# Altium schematic sheet Sheet1.SchDoc(Sheet1)
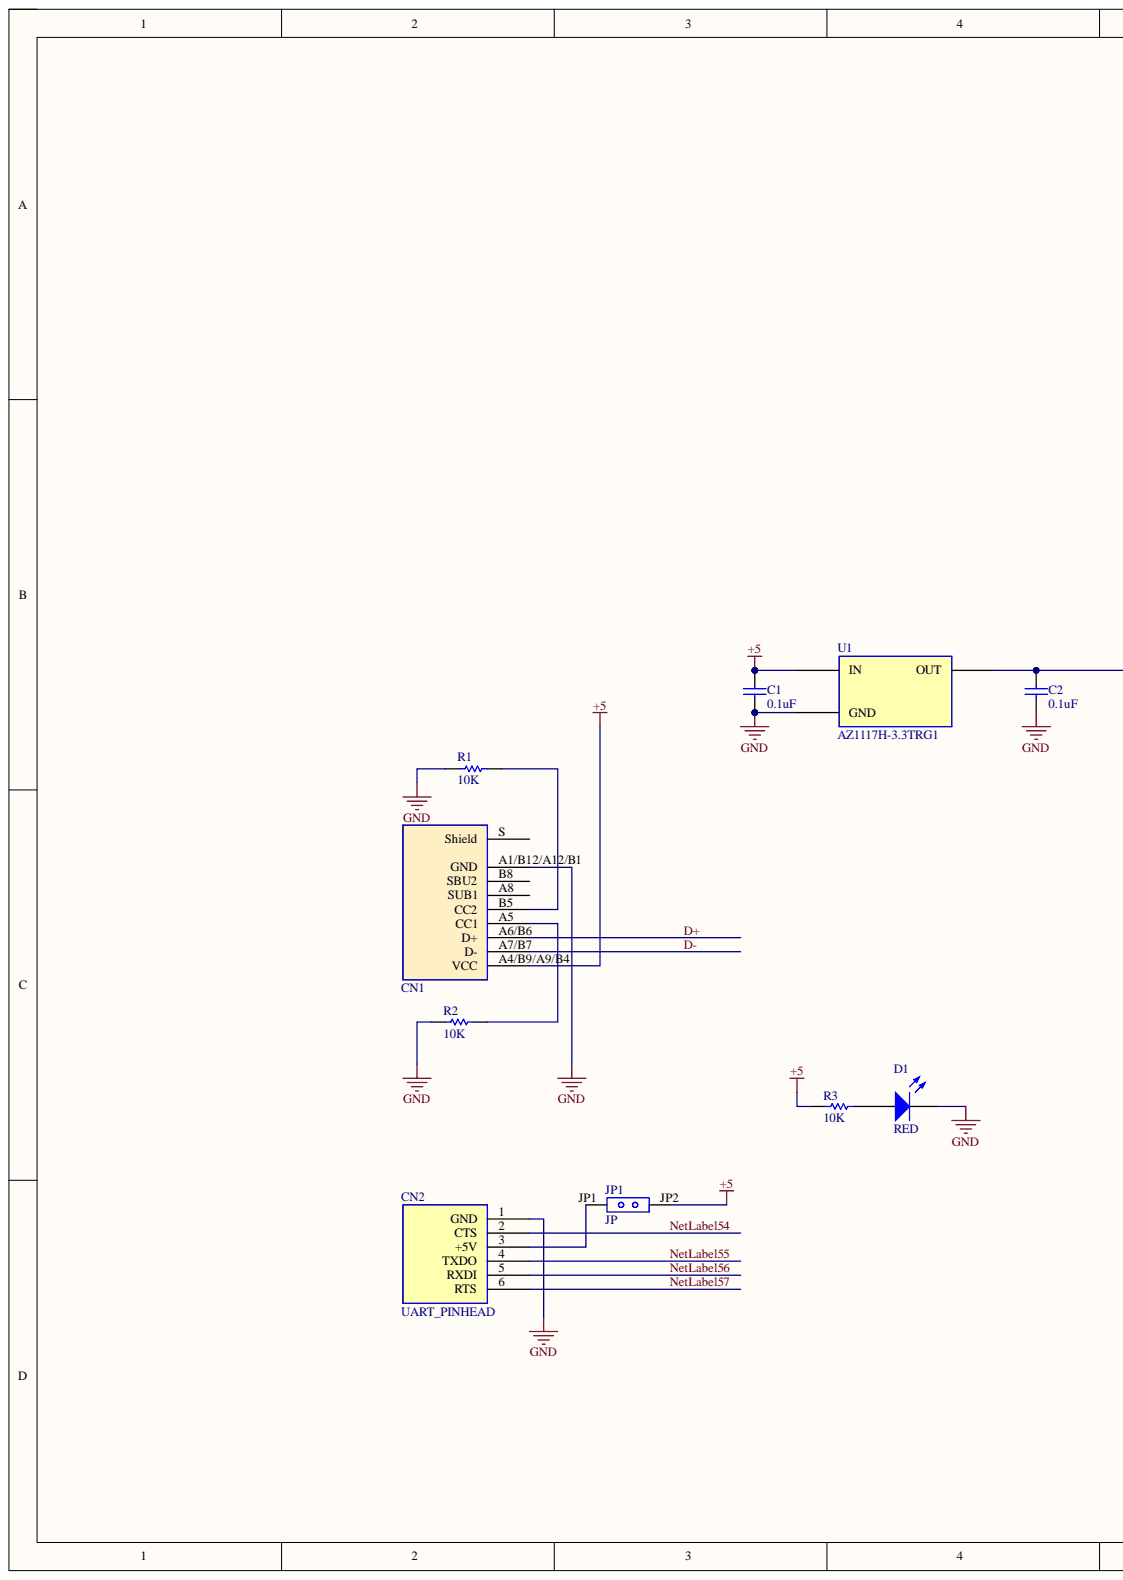
[diagram p0: Sheet1.SchDoc, left]
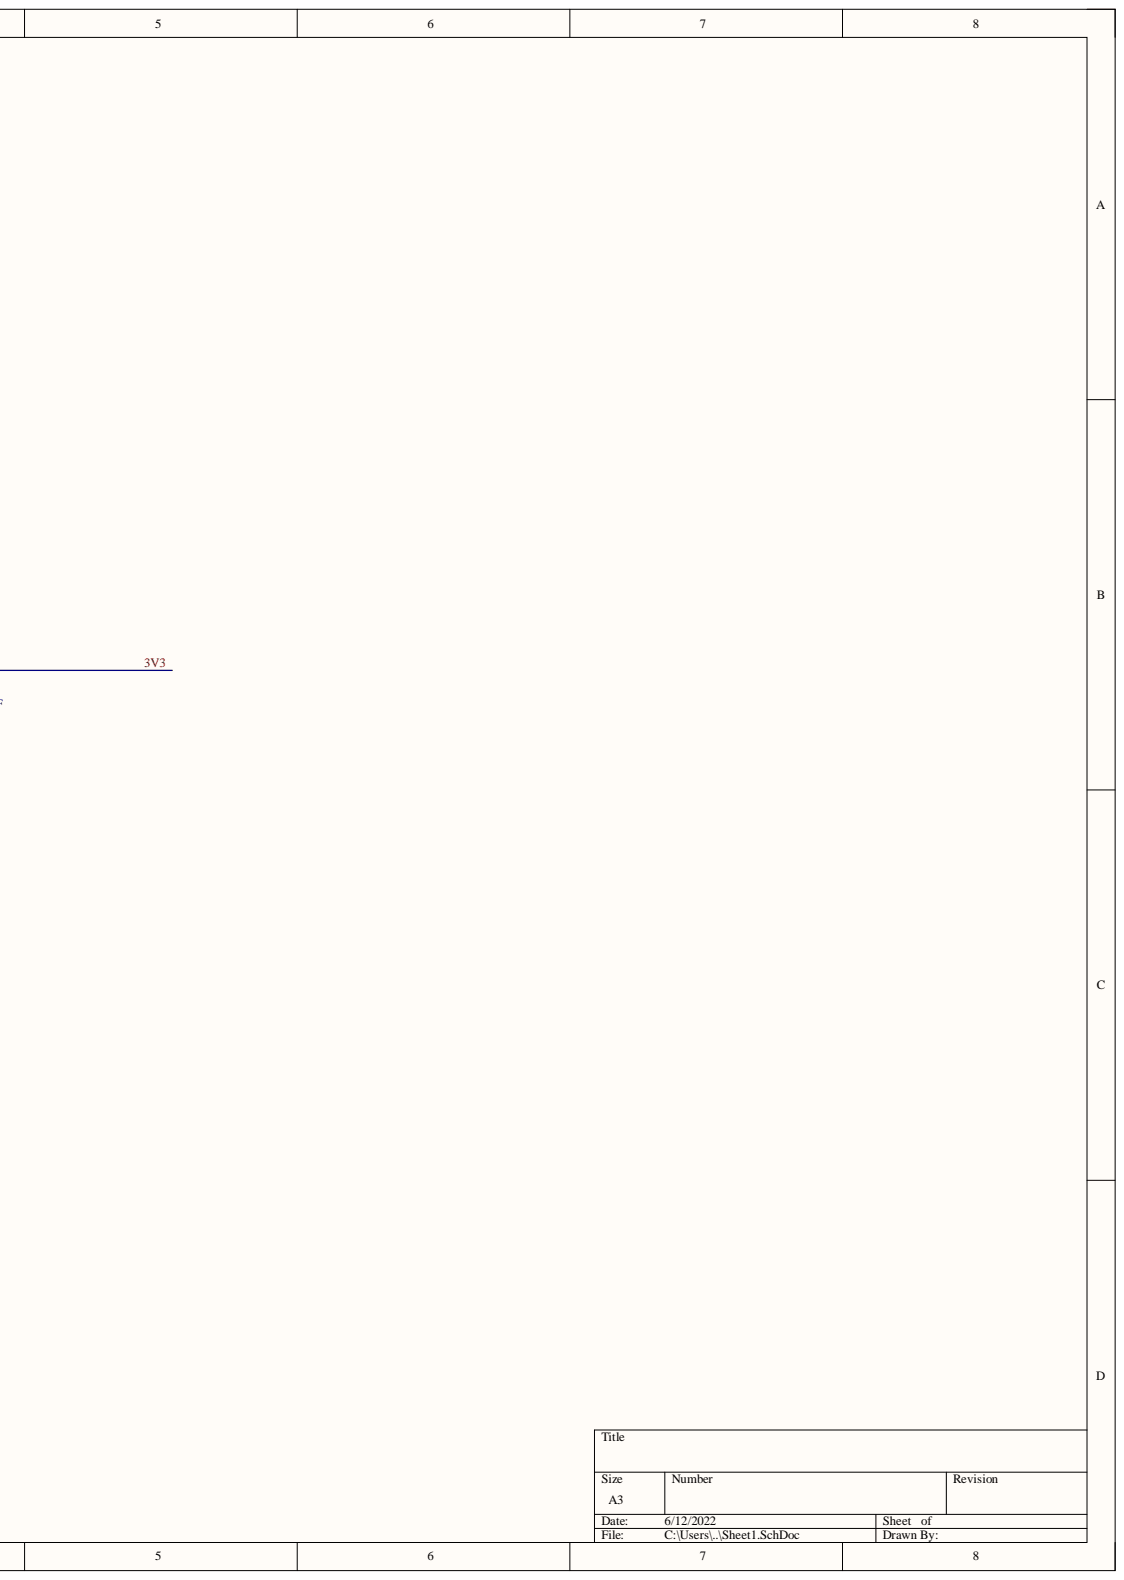
[diagram p1: Sheet1.SchDoc, right]

## Parts
C1  value 0.1uF  [Capacitor_1608]  (hidden comment: Condenser_1608)
C2  value 0.1uF  [Capacitor_1608]  (hidden comment: Condenser_1608)
CN1  USB2.0-Type-C  [TYPE-C-31-M-12]  (value not drawn)
CN2  UART_PINHEAD  [UART_PINHEAD]
D1  value RED  [LED_1608]  (hidden comment: LED_1608)
JP1  JP  [JUMPER_PIN]
R1  value 10K  [Resistance_1608]  (hidden comment: Resista_1608)
R2  value 10K  [Resistance_1608]  (hidden comment: Resista_1608)
R3  value 10K  [Resistance_1608]  (hidden comment: Resista_1608)
U1  AZ1117H-3.3TRG1  [AZ1117H-3.3TRG1]

## Nets
+5: C1.2 CN1.A4/B9/A9/B4(VCC) JP1.JP2 R3.1 U1.3
GND: C1.1 C2.1 CN1.A1/B12/A12/B1(GND) CN2.1(GND) D1.C R1.1 R2.1 U1.1
3V3: C2.2 U1.2
D+: CN1.A6/B6(D+)
D-: CN1.A7/B7(D-)
NetLabel54: CN2.2(CTS)
NetLabel55: CN2.4(TXDO)
NetLabel56: CN2.5(RXDI)
NetLabel57: CN2.6(RTS)
NetCN1_A5: CN1.A5(CC1) R2.2
NetCN1_B5: CN1.B5(CC2) R1.2
NetCN2_3: CN2.3(+5V) JP1.JP1
NetD1_A: D1.A R3.2

Not connected: CN1 A8(SUB1) B8(SBU2) S(Shield)

## Library data of the parts
JP1 = JUMPER_PIN: Component Kind: Standard; Library Name: MyLaibrary.SchLib
C1 C2 = Condenser_1608: Component Kind: Standard; Library Name: MyLaibrary.SchLib
CN1 = USB2.0-Type-C: Component Kind: Standard; Library Name: MyLaibrary.SchLib
CN2 = UART_PINHEAD: Component Kind: Standard; Value: UART_PINHEAD; Library Name: MyLaibrary.SchLib
D1 = LED_1608: Component Kind: Standard; Library Name: MyLaibrary.SchLib
R1-R3 = Resista_1608: Component Kind: Standard; Library Name: MyLaibrary.SchLib
U1 = AZ1117H-3.3TRG1: Component Kind: Standard; Value: AZ1117H-3.3TRG1; Library Name: MyLaibrary.SchLib
